# Altium schematic sheet MA_Connecter.SchDoc
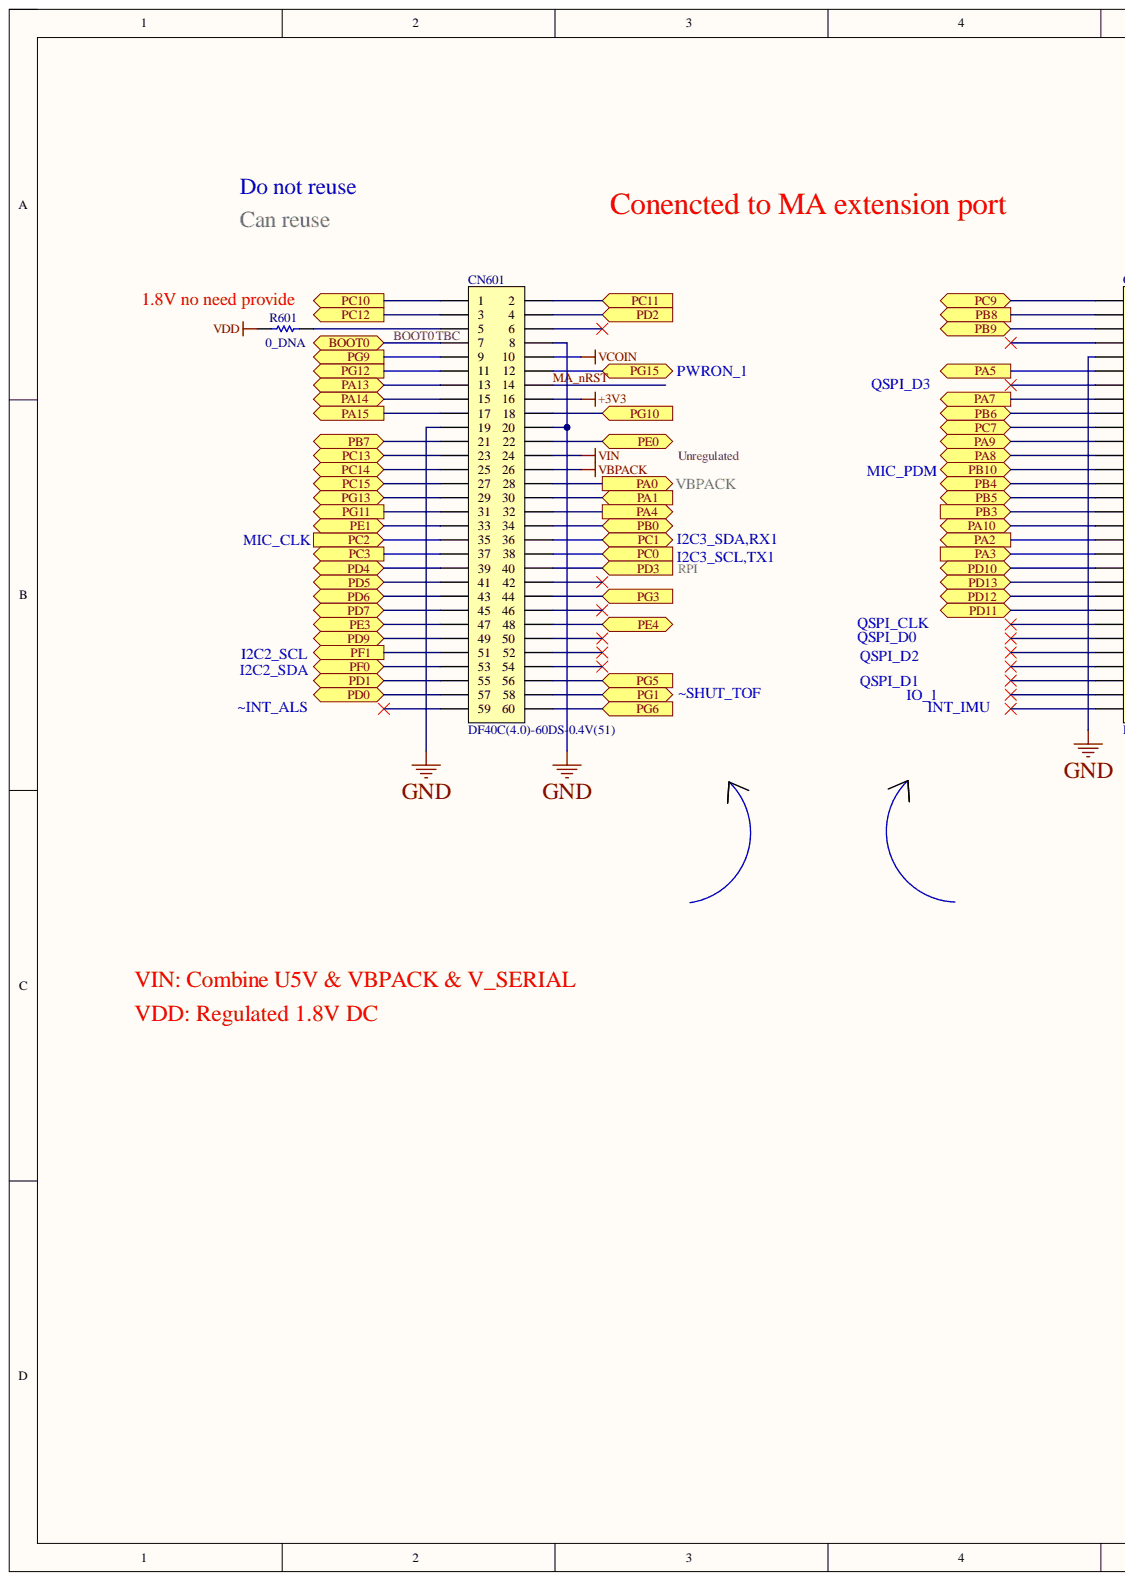
[diagram p0: MA_Connecter.SchDoc, left]
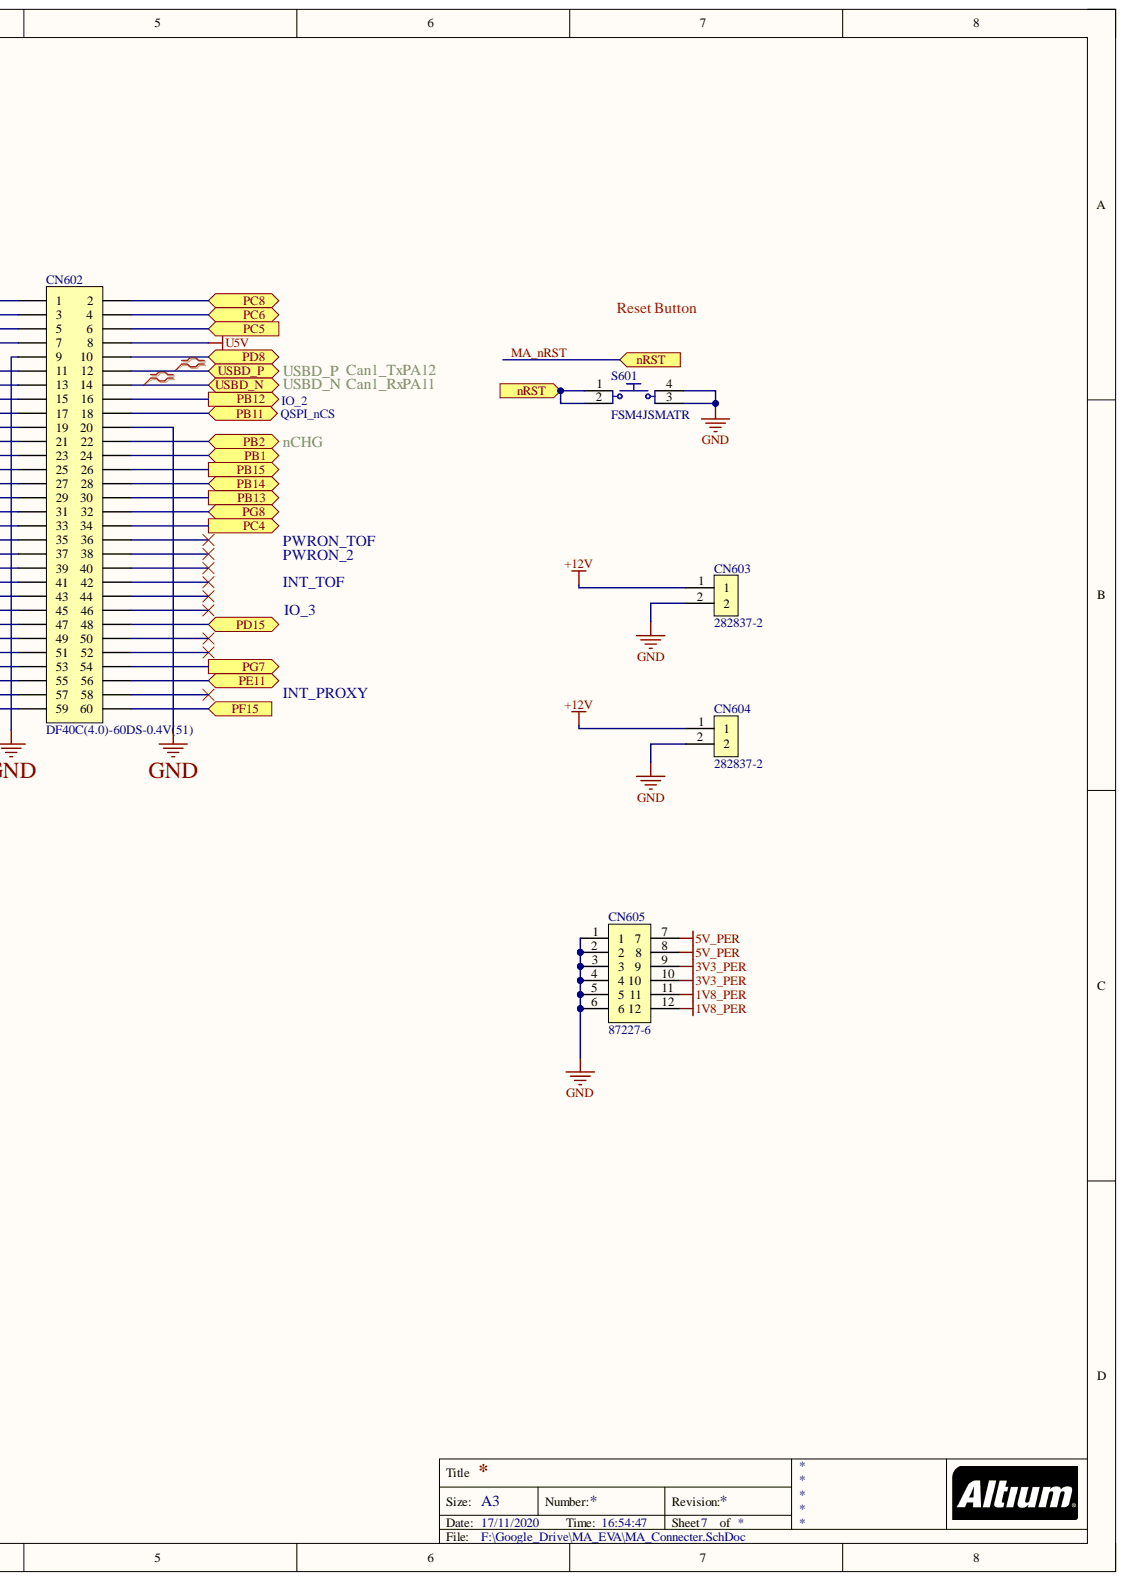
[diagram p1: MA_Connecter.SchDoc, right]

## Parts
CN601  DF40C(4.0)-60DS-0.4V(51)  [DF40HC(4.0)-60DS-0.4V(51)]
CN602  DF40C(4.0)-60DS-0.4V(51)  [DF40HC(4.0)-60DS-0.4V(51)]
CN603  282837-2  [282837-2]
CN604  282837-2  [282837-2]
CN605  87227-6  [87227-6]
R601  0_DNA  [Res 0402]
S601  FSM4JSMATR  [FSM4JSMA]

## Ports
BOOT0, nRST, PA0, PA1, PA2, PA3, PA4, PA5, PA7, PA8, PA9, PA10, PA13, PA14, PA15, PB0, PB1, PB2, PB3, PB4, PB5, PB6, PB7, PB8, PB9, PB10, PB11, PB12, PB13, PB14, PB15, PC0, PC1, PC2, PC3, PC4, PC5, PC6, PC7, PC8, PC9, PC10, PC11, PC12, PC13, PC14, PC15, PD0, PD1, PD2, PD3, PD4, PD5, PD6, PD7, PD8, PD9, PD10, PD11, PD12, PD13, PD15, PE0, PE1, PE3, PE4, PE11, PF0, PF1, PF15, PG1, PG3, PG5, PG6, PG7, PG8, PG9, PG10, PG11, PG12, PG13, PG15, USBD_N, USBD_P

## Nets
1V8_PER: CN605.11 CN605.12
3V3_PER: CN601.16 CN605.9 CN605.10
5V_PER: CN605.7 CN605.8
+12V: CN603.1 CN604.1
GND: CN601.8 CN601.19 CN601.20 CN602.9 CN602.20 CN603.2 CN604.2 CN605.1 CN605.2 CN605.3 CN605.4 CN605.5 CN605.6 S601.3 S601.4
U5V: CN602.8
VBPACK: CN601.26
VCOIN: CN601.10
VDD: R601.1
VIN: CN601.24
BOOT0: CN601.7 ; port BOOT0
MA_nRST: CN601.14 S601.1 S601.2 ; port nRST
PA0: CN601.28 ; port PA0
PA1: CN601.30 ; port PA1
PA2: CN602.35 ; port PA2
PA3: CN602.37 ; port PA3
PA4: CN601.32 ; port PA4
PA5: CN602.11 ; port PA5
PA7: CN602.15 ; port PA7
PA8: CN602.23 ; port PA8
PA9: CN602.21 ; port PA9
PA10: CN602.33 ; port PA10
PA13: CN601.13 ; port PA13
PA14: CN601.15 ; port PA14
PA15: CN601.17 ; port PA15
PB0: CN601.34 ; port PB0
PB1: CN602.24 ; port PB1
PB2: CN602.22 ; port PB2
PB3: CN602.31 ; port PB3
PB4: CN602.27 ; port PB4
PB5: CN602.29 ; port PB5
PB6: CN602.17 ; port PB6
PB7: CN601.21 ; port PB7
PB8: CN602.3 ; port PB8
PB9: CN602.5 ; port PB9
PB10: CN602.25 ; port PB10
PB11: CN602.18 ; port PB11
PB12: CN602.16 ; port PB12
PB13: CN602.30 ; port PB13
PB14: CN602.28 ; port PB14
PB15: CN602.26 ; port PB15
PC0: CN601.38 ; port PC0
PC1: CN601.36 ; port PC1
PC2: CN601.35 ; port PC2
PC3: CN601.37 ; port PC3
PC4: CN602.34 ; port PC4
PC5: CN602.6 ; port PC5
PC6: CN602.4 ; port PC6
PC7: CN602.19 ; port PC7
PC8: CN602.2 ; port PC8
PC9: CN602.1 ; port PC9
PC10: CN601.1 ; port PC10
PC11: CN601.2 ; port PC11
PC12: CN601.3 ; port PC12
PC13: CN601.23 ; port PC13
PC14: CN601.25 ; port PC14
PC15: CN601.27 ; port PC15
PD0: CN601.57 ; port PD0
PD1: CN601.55 ; port PD1
PD2: CN601.4 ; port PD2
PD3: CN601.40 ; port PD3
PD4: CN601.39 ; port PD4
PD5: CN601.41 ; port PD5
PD6: CN601.43 ; port PD6
PD7: CN601.45 ; port PD7
PD8: CN602.10 ; port PD8
PD9: CN601.49 ; port PD9
PD10: CN602.39 ; port PD10
PD11: CN602.45 ; port PD11
PD12: CN602.43 ; port PD12
PD13: CN602.41 ; port PD13
PD15: CN602.48 ; port PD15
PE0: CN601.22 ; port PE0
PE1: CN601.33 ; port PE1
PE3: CN601.47 ; port PE3
PE4: CN601.48 ; port PE4
PE11: CN602.56 ; port PE11
PF0: CN601.53 ; port PF0
PF1: CN601.51 ; port PF1
PF15: CN602.60 ; port PF15
PG1: CN601.58 ; port PG1
PG3: CN601.44 ; port PG3
PG5: CN601.56 ; port PG5
PG6: CN601.60 ; port PG6
PG7: CN602.54 ; port PG7
PG8: CN602.32 ; port PG8
PG9: CN601.9 ; port PG9
PG10: CN601.18 ; port PG10
PG11: CN601.31 ; port PG11
PG12: CN601.11 ; port PG12
PG13: CN601.29 ; port PG13
PG15: CN601.12 ; port PG15
USBD_N: CN602.14 ; port USBD_N
USBD_P: CN602.12 ; port USBD_P
NetCN601_5: CN601.5 R601.2

Not connected: CN601 6 42 46 50 52 54 59; CN602 7 13 36 38 40 42 44 46 47 49 50 51 52 53 55 57 58 59

## Library data of the parts
CN601 CN602 = DF40C(4.0)-60DS-0.4V(51): 60 Position Connector Receptacle, Center Strip Contacts Surface Mount Gold; Component Kind: Standard; Library Name: MA_Eva_Board.SchLib
CN603 CN604 = 282837-2: 282837-2, Motor Connector; Component Kind: Standard; Library Name: MA_Eva_Board.SchLib
CN605 = 87227-6: Power Rail, 5,3.3,1.8; Component Kind: Standard; Library Name: MA_Eva_Board.SchLib
R601 = Res1: ERJ-2GE0R00X, 0402; Component Kind: Standard; LatestRevisionDate: 17-Jul-2002; LatestRevisionNote: Re-released for DXP Platform.; Library Name: Miscellaneous Devices.IntLib; PackageDescription: Axial Device, Thru-Hole; 2 Leads; 0.3 in Pin Spacing; PackageReference: AXIAL-0.3; Published: 8-Jun-2000; Signal Integrity: Res; Simulation: RESISTOR; Publisher: Altium Limited
S601 = FSM4JSMATR: Switch; Component Kind: Standard; Library Name: MA_Eva_Board.SchLib
